# Altium schematic sheet [4]Driver3.SchDoc
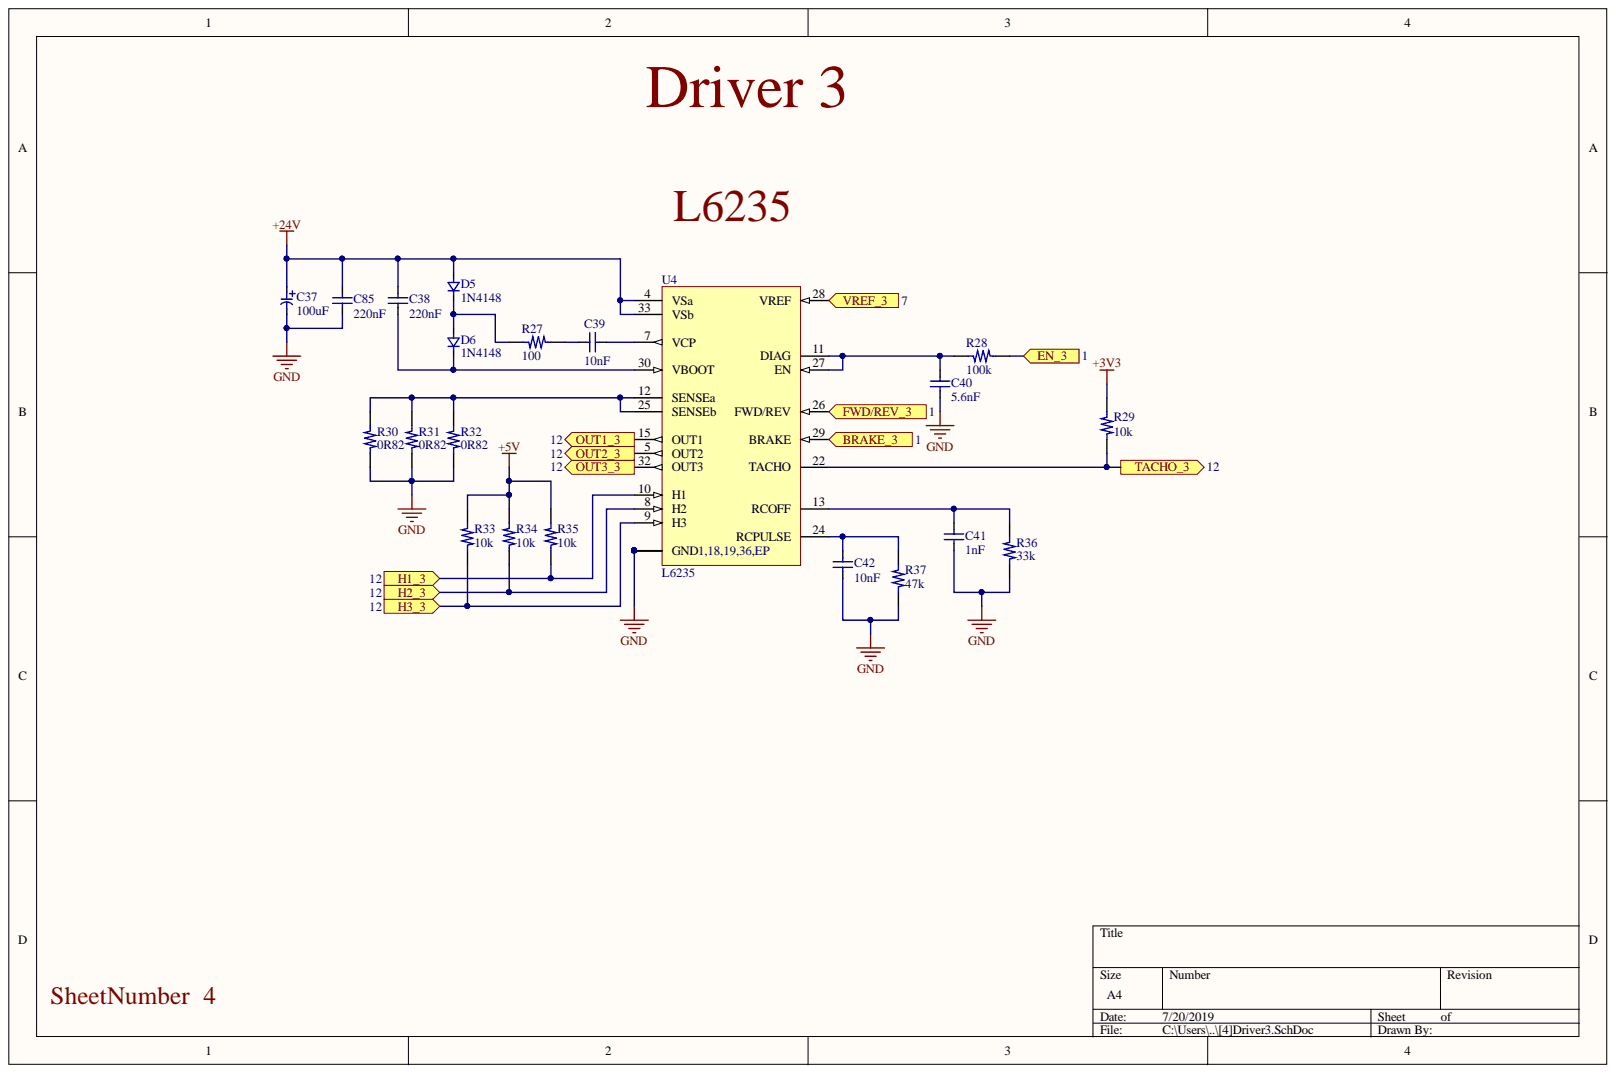
[diagram p0: [4]Driver3.SchDoc]

## Parts
C37  100uF  [Radial SMD 10D]
C38  220nF  [C0805]
C39  10nF  [C0805]
C40  5.6nF  [C0805]
C41  1nF  [C0805]
C42  10nF  [C0805]
C85  220nF  [C0805]
D5  1N4148  [SOD-123]
D6  1N4148  [SOD-123]
R27  100  [R0805]
R28  100k  [R0805]
R29  10k  [R0805]
R30  0R82  [R4122]
R31  0R82  [R4122]
R32  0R82  [R4122]
R33  10k  [R0805]
R34  10k  [R0805]
R35  10k  [R0805]
R36  33k  [R0805]
R37  47k  [R0805]
U4  L6235  [POWERSO36]

## Ports
BRAKE_3, EN_3, FWD/REV_3, H1_3, H2_3, H3_3, OUT1_3, OUT2_3, OUT3_3, TACHO_3, VREF_3

## Nets
+3V3: R29.2
+5V: R33.1 R34.1 R35.1
+24V: C37.1 C38.2 C85.2 D5.1 U4.4(VSa) U4.33(VSb)
GND: C37.2 C40.2 C41.1 C42.1 C85.1 R30.1 R31.1 R32.1 R36.1 R37.1 U4.1 U4.18 U4.19 U4.36 U4.37
BRAKE_3: U4.29(BRAKE) ; port BRAKE_3
EN_3: R28.2 ; port EN_3
FWD/REV_3: U4.26(FWD/REV) ; port FWD/REV_3
H1_3: R35.2 U4.10(H1) ; port H1_3
H2_3: R34.2 U4.8(H2) ; port H2_3
H3_3: R33.2 U4.9(H3) ; port H3_3
OUT1_3: U4.15(OUT1) ; port OUT1_3
OUT2_3: U4.5(OUT2) ; port OUT2_3
OUT3_3: U4.32(OUT3) ; port OUT3_3
TACHO_3: R29.1 U4.22(TACHO) ; port TACHO_3
VREF_3: U4.28(VREF) ; port VREF_3
NetC38_1: C38.1 D6.2 U4.30(VBOOT)
NetC39_1: C39.1 R27.2
NetC39_2: C39.2 U4.7(VCP)
NetC40_1: C40.1 R28.1 U4.11(DIAG) U4.27(EN)
NetC41_2: C41.2 R36.2 U4.13(RCOFF)
NetC42_2: C42.2 R37.2 U4.24(RCPULSE)
NetD5_2: D5.2 D6.1 R27.1
NetR30_2: R30.2 R31.2 R32.2 U4.12(SENSEa) U4.25(SENSEb)

## Library data of the parts
C37 = EEE1JA101P: CAP ALUM 100UF 20% 63V SMD; Mfr 1: Panasonic Electronic Components; Mfr 1 PN: EEE-1JA101P; Component Kind: Standard; Library Name: Components.SchLib
C38 C85 = C0805C224K5RACAUTO: CAP CER 0.22UF 50V X7R 0805; Mfr 1: KEMET; Component Kind: Standard; Library Name: Components.SchLib; Mfr 1 PN: C0805C224K5RACAUTO
C39 C42 = CL21B103KBANFNC: CAP CER 10000PF 50V X7R 0805; Mfr 1: Samsung Electro-Mechanics; Component Kind: Standard; Library Name: Components.SchLib; Mfr 1 PN: CL21B103KBANFNC
C40 = CL21B562KBANNNC: CAP CER 5600PF 50V X7R 0805; Mfr 1: Samsung Electro-Mechanics; Component Kind: Standard; Library Name: Components.SchLib; Mfr 1 PN: CL21B562KBANNNC
C41 = CL21B102KBANFNC: CAP CER 1000PF 50V X7R 0805; Mfr 1: Samsung Electro-Mechanics; Component Kind: Standard; Library Name: Components.SchLib; Mfr 1 PN: CL21B102KBANFNC
D5 D6 = 1N4148W-G RHG: DIODE GEN PURP 100V 150MA SOD123; Mfr 1: Taiwan Semiconductor Corporation; Component Kind: Standard; Library Name: Components.SchLib; Mfr 1 PN: 1N4148W-G RHG
R27 = ESR10EZPF1000: RES SMD 100 OHM 1% 0.4W 0805; Mfr 1: Rohm Semiconductor; Component Kind: Standard; Library Name: Components.SchLib; Mfr 1 PN: ESR10EZPF1000
R28 = RC0805FR-07100KL: RES SMD 100K OHM 1% 1/8W 0805; Mfr 1: Yageo; Component Kind: Standard; Library Name: Components.SchLib; Mfr 1 PN: RC0805FR-07100KL
R29 = CRG0805F10K/10: RES SMD 10K OHM 1% 1/8W 0805; Mfr 1: TE Connectivity Passive Product; Component Kind: Standard; Library Name: Components.SchLib; Mfr 1 PN: CRG0805F10K/10
R30-R32 = SMW3R82JT: RES 0.82 OHM 5% 3W 4122; Mfr 1: TE Connectivity Passive Product; Component Kind: Standard; Library Name: Components.SchLib; Mfr 1 PN: SMW3R82JT
R33-R35 = RC0805FR-0710KL: RES SMD 10K OHM 1% 1/8W 0805; Mfr 1: Yageo; Component Kind: Standard; Library Name: Components.SchLib; Mfr 1 PN: RC0805FR-0710KL
R36 = RMCF0805FT33K0: RES 33K OHM 1% 1/8W 0805; Mfr 1: Stackpole Electronics Inc.; Component Kind: Standard; Library Name: Components.SchLib; Mfr 1 PN: RMCF0805FT33K0
R37 = RC0805FR-0747KL: RES SMD 47K OHM 1% 1/8W 0805; Mfr 1: Yageo; Component Kind: Standard; Library Name: Components.SchLib; Mfr 1 PN: RC0805FR-0747KL
U4 = L6235PD: IC MOTOR DRIVER PAR 36POWERSO; Mfr 1: STMicroelectronics; Component Kind: Standard; Library Name: Components.SchLib; Mfr 1 PN: L6235PD
